# Altium schematic sheet Module.SchDoc(U_MODULE)
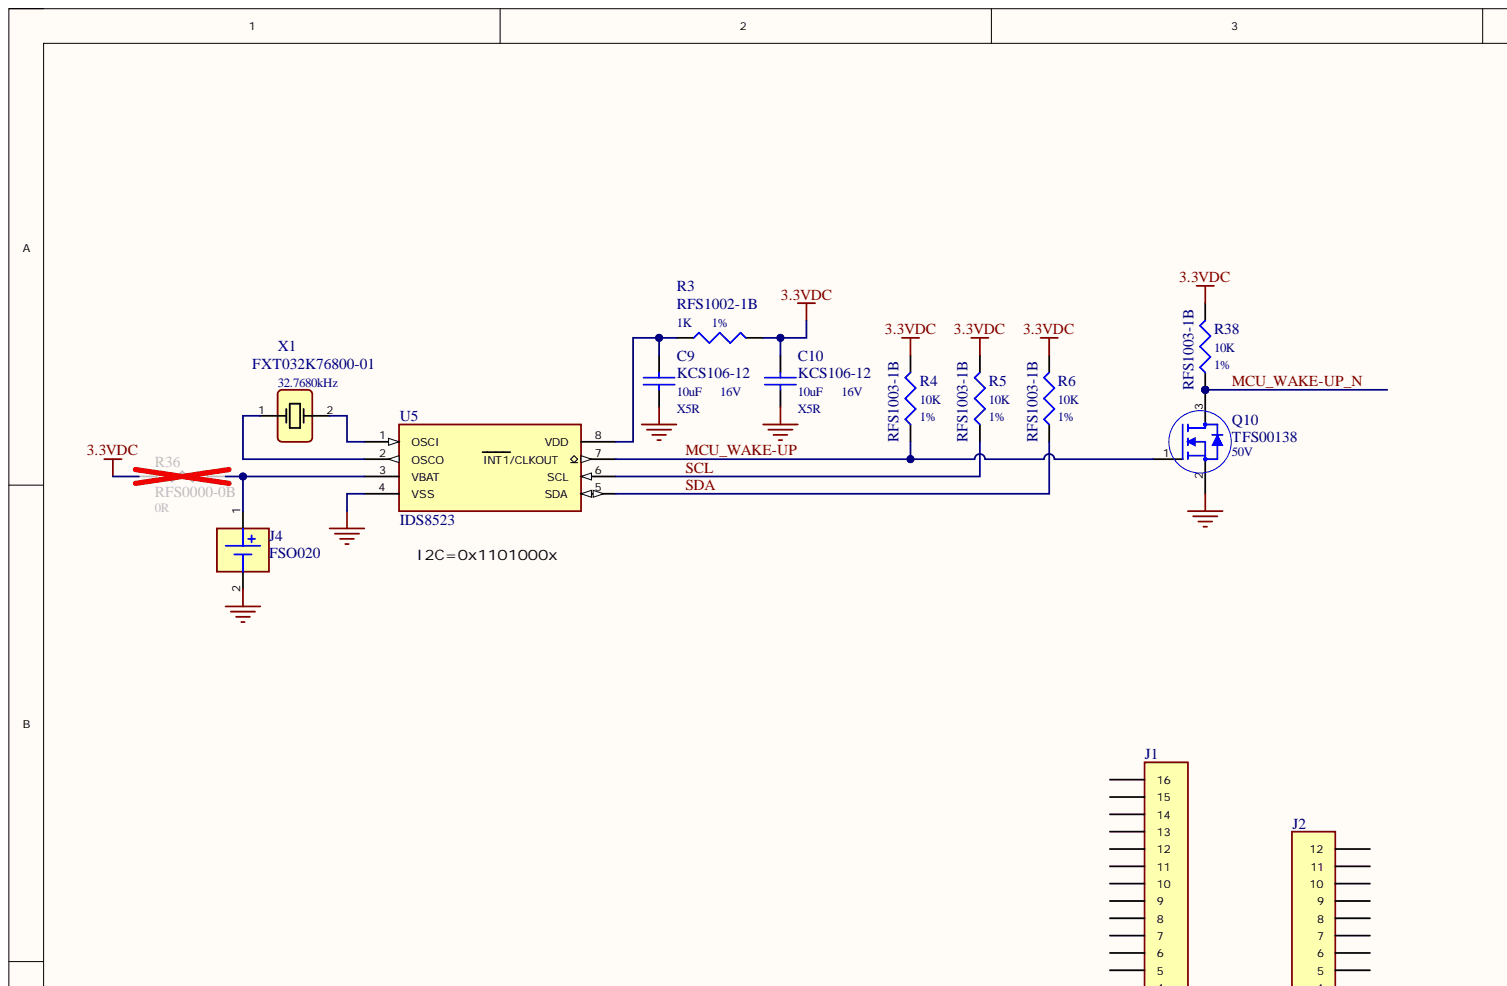
[diagram p0: Module.SchDoc, top left]
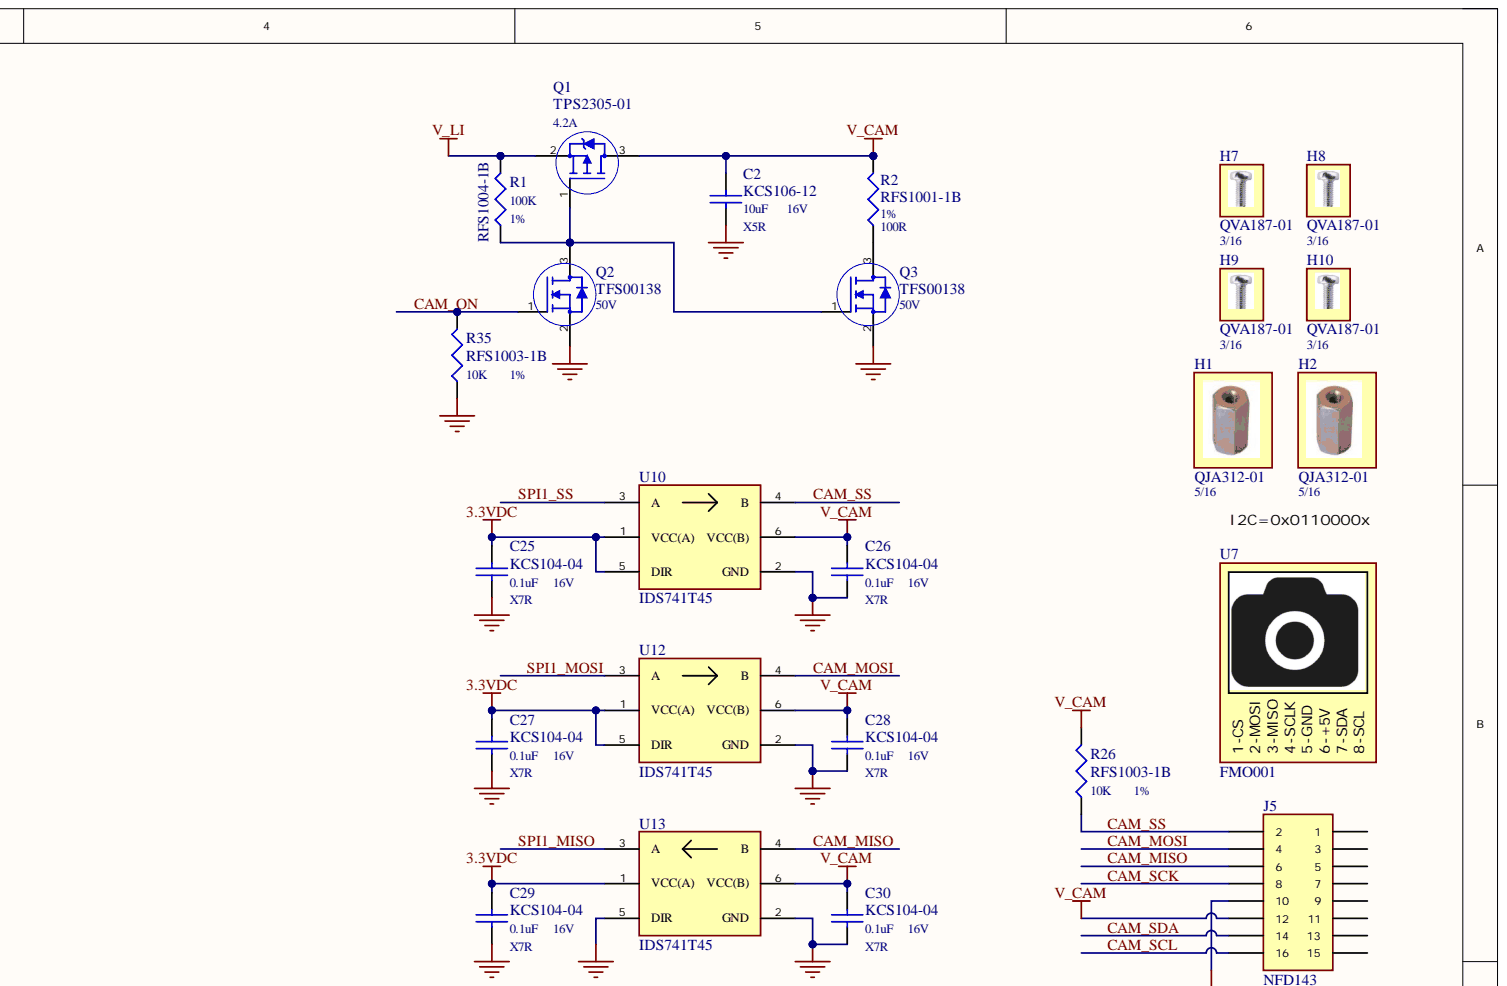
[diagram p1: Module.SchDoc, top right]
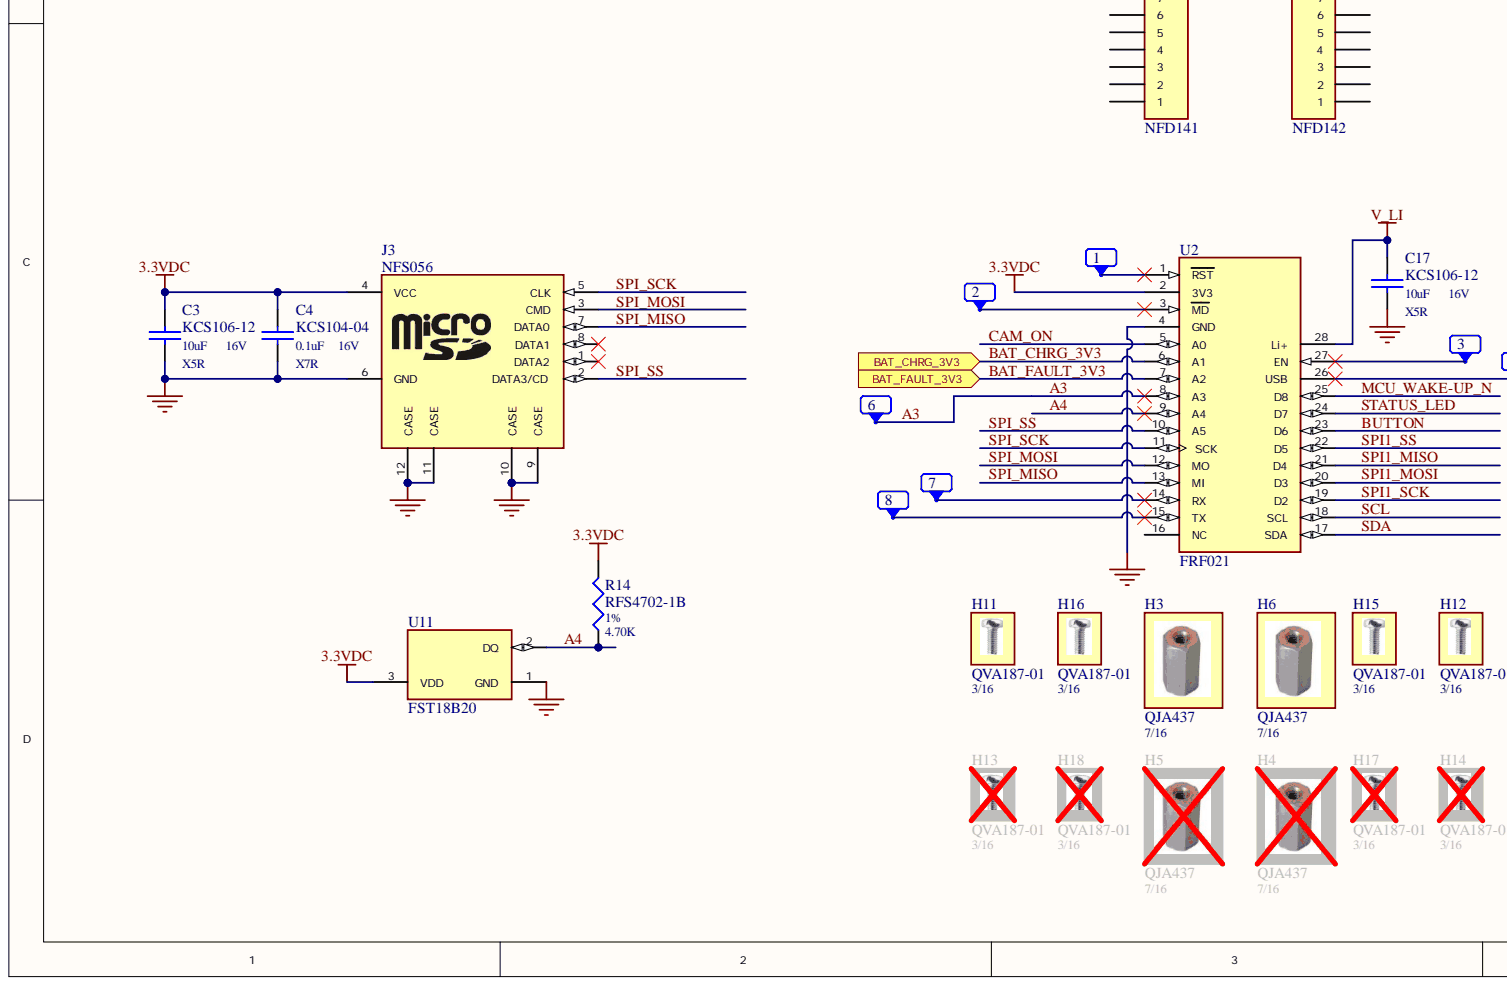
[diagram p2: Module.SchDoc, bottom left]
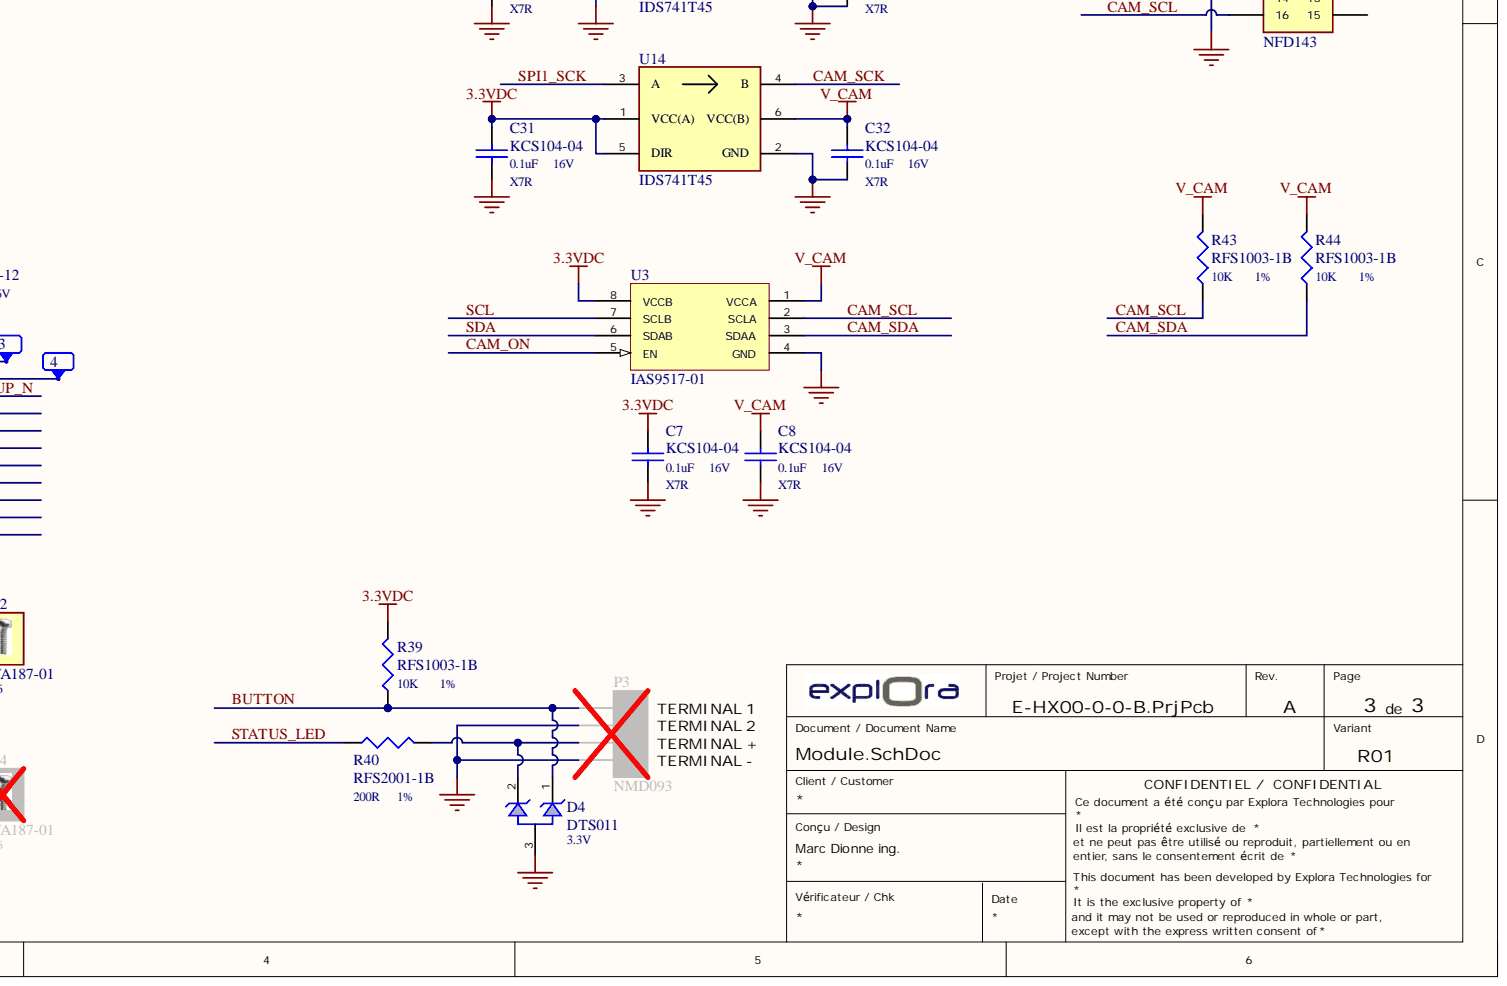
[diagram p3: Module.SchDoc, bottom right]

## Parts
1  TESTPOINT_SMALL  [TESTP_THRU - SMALL]  (value not drawn)  (no BOM)
2  TESTPOINT_SMALL  [TESTP_THRU - SMALL]  (value not drawn)  (no BOM)
3  TESTPOINT_SMALL  [TESTP_THRU - SMALL]  (value not drawn)  (no BOM)
4  TESTPOINT_SMALL  [TESTP_THRU - SMALL]  (value not drawn)  (no BOM)
6  TESTPOINT_SMALL  [TESTP_THRU - SMALL]  (value not drawn)  (no BOM)
7  TESTPOINT_SMALL  [TESTP_THRU - SMALL]  (value not drawn)  (no BOM)
8  TESTPOINT_SMALL  [TESTP_THRU - SMALL]  (value not drawn)  (no BOM)
C2  KCS106-12  1_VAL: 10uF  7_TYPE: X5R  [0805]
C3  KCS106-12  1_VAL: 10uF  7_TYPE: X5R  [0805]
C4  KCS104-04  1_VAL: 0.1uF  3_V: 16V  7_TYPE: X7R  [0402]
C7  KCS104-04  1_VAL: 0.1uF  7_TYPE: X7R  [0402]
C8  KCS104-04  1_VAL: 0.1uF  3_V: 16V  7_TYPE: X7R  [0402]
C9  KCS106-12  1_VAL: 10uF  7_TYPE: X5R  [0805]
C10  KCS106-12  1_VAL: 10uF  3_V: 16V  7_TYPE: X5R  [0805]
C17  KCS106-12  1_VAL: 10uF  7_TYPE: X5R  [0805]
C25  KCS104-04  1_VAL: 0.1uF  7_TYPE: X7R  [0402]
C26  KCS104-04  1_VAL: 0.1uF  3_V: 16V  7_TYPE: X7R  [0402]
C27  KCS104-04  1_VAL: 0.1uF  7_TYPE: X7R  [0402]
C28  KCS104-04  1_VAL: 0.1uF  3_V: 16V  7_TYPE: X7R  [0402]
C29  KCS104-04  1_VAL: 0.1uF  7_TYPE: X7R  [0402]
C30  KCS104-04  1_VAL: 0.1uF  3_V: 16V  7_TYPE: X7R  [0402]
C31  KCS104-04  1_VAL: 0.1uF  7_TYPE: X7R  [0402]
C32  KCS104-04  1_VAL: 0.1uF  3_V: 16V  7_TYPE: X7R  [0402]
D4  DTS011  3_V: 3.3V  [SOT23]
H1  QJA312-01  1_VAL: 5/16  [QJA312-01]
H2  QJA312-01  1_VAL: 5/16  [QJA312-01]
H3  QJA437  1_VAL: 7/16  [QJA437]
H4  QJA437  1_VAL: 7/16  [QJA437]
H5  QJA437  1_VAL: 7/16  [QJA437]
H6  QJA437  1_VAL: 7/16  [QJA437]
H7  QVA187-01  [QVA187-01]
H8  QVA187-01  [QVA187-01]
H9  QVA187-01  1_VAL: 3/16  [QVA187-01]
H10  QVA187-01  1_VAL: 3/16  [QVA187-01]
H11  QVA187-01  1_VAL: 3/16  [QVA187-01]
H12  QVA187-01  1_VAL: 3/16  [QVA187-01]
H13  QVA187-01  1_VAL: 3/16  [QVA187-01]
H14  QVA187-01  1_VAL: 3/16  [QVA187-01]
H15  QVA187-01  1_VAL: 3/16  [QVA187-01]
H16  QVA187-01  1_VAL: 3/16  [QVA187-01]
H17  QVA187-01  1_VAL: 3/16  [QVA187-01]
H18  QVA187-01  1_VAL: 3/16  [QVA187-01]
J1  NFD141  [NFD141 - WITHOUT PAD]
J2  NFD142  [NFD142 - WITHOUT PAD]
J3  NFS056  [NFS056]
J4  FSO020  [FSO020]
J5  NFD143  [NFD143]
P3  NMD093  [NMD093]
Q1  TPS2305-01  4_I: 4.2A  [SOT23]
Q2  TFS00138  3_V: 50V  [SOT23]
Q3  TFS00138  3_V: 50V  [SOT23]
Q10  TFS00138  3_V: 50V  [SOT23]
R1  RFS1004-1B  1_VAL: 100K  2_%: 1%  [0402]
R2  RFS1001-1B  1_VAL: 100R  2_%: 1%  [0402]
R3  RFS1002-1B  1_VAL: 1K  2_%: 1%  [0402]
R4  RFS1003-1B  1_VAL: 10K  2_%: 1%  [0402]
R5  RFS1003-1B  1_VAL: 10K  2_%: 1%  [0402]
R6  RFS1003-1B  1_VAL: 10K  2_%: 1%  [0402]
R14  RFS4702-1B  1_VAL: 4.70K  2_%: 1%  [0402]
R26  RFS1003-1B  1_VAL: 10K  [0402]
R35  RFS1003-1B  1_VAL: 10K  [0402]
R36  RFS0000-0B  1_VAL: 0R  [0402]
R38  RFS1003-1B  1_VAL: 10K  2_%: 1%  [0402]
R39  RFS1003-1B  1_VAL: 10K  [0402]
R40  RFS2001-1B  1_VAL: 200R  2_%: 1%  [0402]
R43  RFS1003-1B  1_VAL: 10K  [0402]
R44  RFS1003-1B  1_VAL: 10K  2_%: 1%  [0402]
U2  FRF021  [FP-BRN402-0 - standoff 8.5mm]
U3  IAS9517-01  [MSOP8]
U5  IDS8523  [SOIC8]
U7  FMO001  [FMO001]
U10  IDS741T45  [SOT363]
U11  FST18B20  [TO-92-3]
U12  IDS741T45  [SOT363]
U13  IDS741T45  [SOT363]
U14  IDS741T45  [SOT363]
X1  FXT032K76800-01  1_VAL: 32.7680kHz  [ABSL06L]

## Ports
BAT_CHRG_3V3, BAT_FAULT_3V3

## Nets
3.3VDC: C3.1 C4.1 C7.1 C10.1 C25.1 C27.1 C29.1 C31.1 J3.4(VCC) R3.2 R4.2 R5.2 R6.2 R14.2 R36.1 R38.2 R39.2 U2.2(3V3) U3.8(VCCB) U10.1(VCC(A)) U10.5(DIR) U11.3 U12.1(VCC(A)) U12.5(DIR) U13.1(VCC(A)) U14.1(VCC(A)) U14.5(DIR)
GND: C2.2 C3.2 C4.2 C7.2 C8.2 C9.2 C10.2 C17.2 C25.2 C26.2 C27.2 C28.2 C29.2 C30.2 C31.2 C32.2 D4.3 J3.6(GND) J3.9(CASE) J3.10(CASE) J3.11(CASE) J3.12(CASE) J4.2 J5.10 P3.2 P3.4 Q2.2 Q3.2 Q10.2 R35.1 U2.4(GND) U3.4(GND) U5.4(VSS) U10.2(GND) U11.1 U12.2(GND) U13.2(GND) U13.5(DIR) U14.2(GND)
V_CAM: C2.1 C8.1 C26.1 C28.1 C30.1 C32.1 J5.12 Q1.3 R2.2 R26.2 R43.2 R44.2 U3.1(VCCA) U10.6(VCC(B)) U12.6(VCC(B)) U13.6(VCC(B)) U14.6(VCC(B))
V_LI: C17.1 Q1.2 R1.2 U2.28(Li+)
A3: 6.1 U2.8(A3)
A4: R14.1 U2.9(A4) U11.2
BAT_CHRG_3V3: U2.6(A1) ; port BAT_CHRG_3V3
BAT_FAULT_3V3: U2.7(A2) ; port BAT_FAULT_3V3
BUTTON: D4.1 P3.1 R39.1 U2.23(D6)
CAM_MISO: J5.6 U13.4(B)
CAM_MOSI: J5.4 U12.4(B)
CAM_ON: Q2.1 R35.2 U2.5(A0) U3.5(EN)
CAM_SCK: J5.8 U14.4(B)
CAM_SCL: J5.16 R43.1 U3.2(SCLA)
CAM_SDA: J5.14 R44.1 U3.3(SDAA)
CAM_SS: J5.2 R26.1 U10.4(B)
MCU_WAKE-UP: Q10.1 R4.1 U5.7(INT1/CLKOUT)
MCU_WAKE-UP_N: Q10.3 R38.1 U2.25(D8)
SCL: R5.1 U2.18(SCL) U3.7(SCLB) U5.6(SCL)
SDA: R6.1 U2.17(SDA) U3.6(SDAB) U5.5(SDA)
SPI1_MISO: U2.21(D4) U13.3(A)
SPI1_MOSI: U2.20(D3) U12.3(A)
SPI1_SCK: U2.19(D2) U14.3(A)
SPI1_SS: U2.22(D5) U10.3(A)
SPI_MISO: J3.7(DATA0) U2.13(MI)
SPI_MOSI: J3.3(CMD) U2.12(MO)
SPI_SCK: J3.5(CLK) U2.11(SCK)
SPI_SS: J3.2(DATA3/CD) U2.10(A5)
STATUS_LED: R40.1 U2.24(D7)
Net1_1: 1.1 U2.1(RST)
Net2_1: 2.1 U2.3(MD)
Net3_1: 3.1 U2.27(EN)
Net4_1: 4.1 U2.26(USB)
Net7_1: 7.1 U2.14(RX)
Net8_1: 8.1 U2.15(TX)
NetC9_1: C9.1 R3.1 U5.8(VDD)
NetD4_2: D4.2 P3.3 R40.2
NetJ4_1: J4.1 R36.2 U5.3(VBAT)
NetQ1_1: Q1.1 Q2.3 Q3.1 R1.1
NetQ3_3: Q3.3 R2.1
NetU5_1: U5.1(OSCI) X1.2
NetU5_2: U5.2(OSCO) X1.1

Not connected: J1 1 2 3 4 5 6 7 8 9 10 11 12 13 14 15 16; J2 1 2 3 4 5 6 7 8 9 10 11 12; J3 1(DATA2) 8(DATA1); J5 1 3 5 7 9 11 13 15; U2 16(NC)

## Library data of the parts
1-4 6-8 = TESTPOINT_SMALL: TESTPOINT, THRU-HOLE SMALL; Library Name: MAIN.SchLib
C2 C3 C9 C17 = KCS106-12: Condensateur céramique; 2_%: 10%; 3_V: 16V; Component Kind: Standard; Library Name: CAPACITORS.SchLib
C4 C8 C26 C28 C30 C32 = KCS104-04: Condensateur céramique; 2_%: 10%; Component Kind: Standard; Library Name: CAPACITORS.SchLib
C7 C25 C27 C29 C31 = KCS104-04: Condensateur céramique; 2_%: 10%; 3_V: 16V; Component Kind: Standard; Library Name: CAPACITORS.SchLib
C10 = KCS106-12: Condensateur céramique; 2_%: 10%; Component Kind: Standard; Library Name: CAPACITORS.SchLib
D4 = DTS011: TVS 3.3V UNIDIR DUAL SOT23; Component Kind: Standard; Library Name: DIODES&LCD.SchLib
H1 H2 = QJA312-01: STANDOFF HEX F/F 2-56 STAINLESS STEEL 5/16; Component Kind: Standard; Library Name: MAIN.SchLib
H3-H6 = QJA437: STANDOFF HEX F/F 2-56 STAINLESS STEEL 7/16; Component Kind: Standard; Library Name: MAIN.SchLib
H7 H8 = QVA187-01: VIS 2-56 Narrow Fillister Head Phillips Screws  High-Profile, 3/16" Long; 1_VAL: 3/16; Component Kind: Standard; Library Name: MAIN.SchLib
H9-H18 = QVA187-01: VIS 2-56 Narrow Fillister Head Phillips Screws  High-Profile, 3/16" Long; Component Kind: Standard; Library Name: MAIN.SchLib
J1 = NFD141: 16 Position Header Connector Through Hole (0.100, 1x16); Component Kind: Standard; Library Name: CONNECTORS.SchLib
J2 = NFD142: 12 Position Header Connector Through Hole (0.100, 1x12); Component Kind: Standard; Library Name: CONNECTORS.SchLib
J3 = NFS056: CONNECTEUR MICRO SD HINGE; Component Kind: Standard; Library Name: CONNECTORS.SchLib
J4 = FSO020: Battery Holder (Open) Coin, 12.5mm 1 Cell PC Pin; Component Kind: Standard; Library Name: MAIN.SchLib
J5 = NFD143: CONN HDR 16POS 0.1 GOLD PCB R/A (8x2); Component Kind: Standard; Library Name: CONNECTORS.SchLib
P3 = NMD093: CONN HEADER 4POS 100MIL; Component Kind: Standard; Library Name: CONNECTORS.SchLib
Q1 = TPS2305-01: MOSFET P; 3_V: VDS=20V  VGS=8V; Component Kind: Standard; Library Name: TRANSISTORS.SchLib
Q2 Q3 Q10 = TFS00138: N-CH ENHANCEMENT MODE FET; Component Kind: Standard; Library Name: TRANSISTORS.SchLib; Simulation: bss138lt1
R1 = RFS1004-1B: Resistance Fixe Smt; 5_W: 0.0625W; Component Kind: Standard; Library Name: RESISTORS.SchLib
R2 = RFS1001-1B: Resistance Fixe Smt; 5_W: 0.0625W; Component Kind: Standard; Library Name: RESISTORS.SchLib
R3 = RFS1002-1B: Resistance Fixe Smt; 5_W: 0.0625W; Component Kind: Standard; Library Name: RESISTORS.SchLib
R4-R6 R38 R44 = RFS1003-1B: Resistance Fixe Smt; 5_W: 0.0625W; Component Kind: Standard; Library Name: RESISTORS.SchLib
R14 = RFS4702-1B: Resistance Fixe Smt; 5_W: 0.0625W; Component Kind: Standard; Library Name: RESISTORS.SchLib
R26 R35 R39 R43 = RFS1003-1B: Resistance Fixe Smt; 2_%: 1%; 5_W: 0.0625W; Component Kind: Standard; Library Name: RESISTORS.SchLib
R36 = RFS0000-0B: Résistance fixe SMT; 2_%: 5%; 5_W: 1/16W; Component Kind: Standard; Library Name: RESISTORS.SchLib
R40 = RFS2001-1B: Resistance Fixe Smt; 5_W: 1/16W; Component Kind: Standard; Library Name: RESISTORS.SchLib
U2 = FRF021: BORON LTE; Component Kind: Standard; Library Name: MAIN.SchLib
U3 = IAS9517-01: LEVEL TRANSLATING I2C-BUS REPEATER; Component Kind: Standard; Library Name: IC.SchLib
U5 = IDS8523: Real Time Clock (RTC) IC Clock/Calendar I²C, 2-Wire Serial 8-SOIC (0.154", 3.90mm Width); Component Kind: Standard; Library Name: IC.SchLib
U7 = FMO001: Module caméra; Component Kind: Standard; Library Name: MAIN.SchLib
U10 U12-U14 = IDS741T45: Voltage Level Translator Bidirectional 1 Circuit 1 Channel 420Mbps 6-TSSOP; Component Kind: Standard; Library Name: IC.SchLib
U11 = FST18B20: Temperature Sensor Digital, Local -55°C ~ 125°C 12 b TO-92-3, 1-Wire; Component Kind: Standard; Library Name: MAIN.SchLib
X1 = FXT032K76800-01: Crystal 32.7680kHz 2-SMD; 5_W: 100uW TYP; Component Kind: Standard; Library Name: MAIN.SchLib
